# Altium schematic sheet Sheet1.SchDoc(Sheet1)
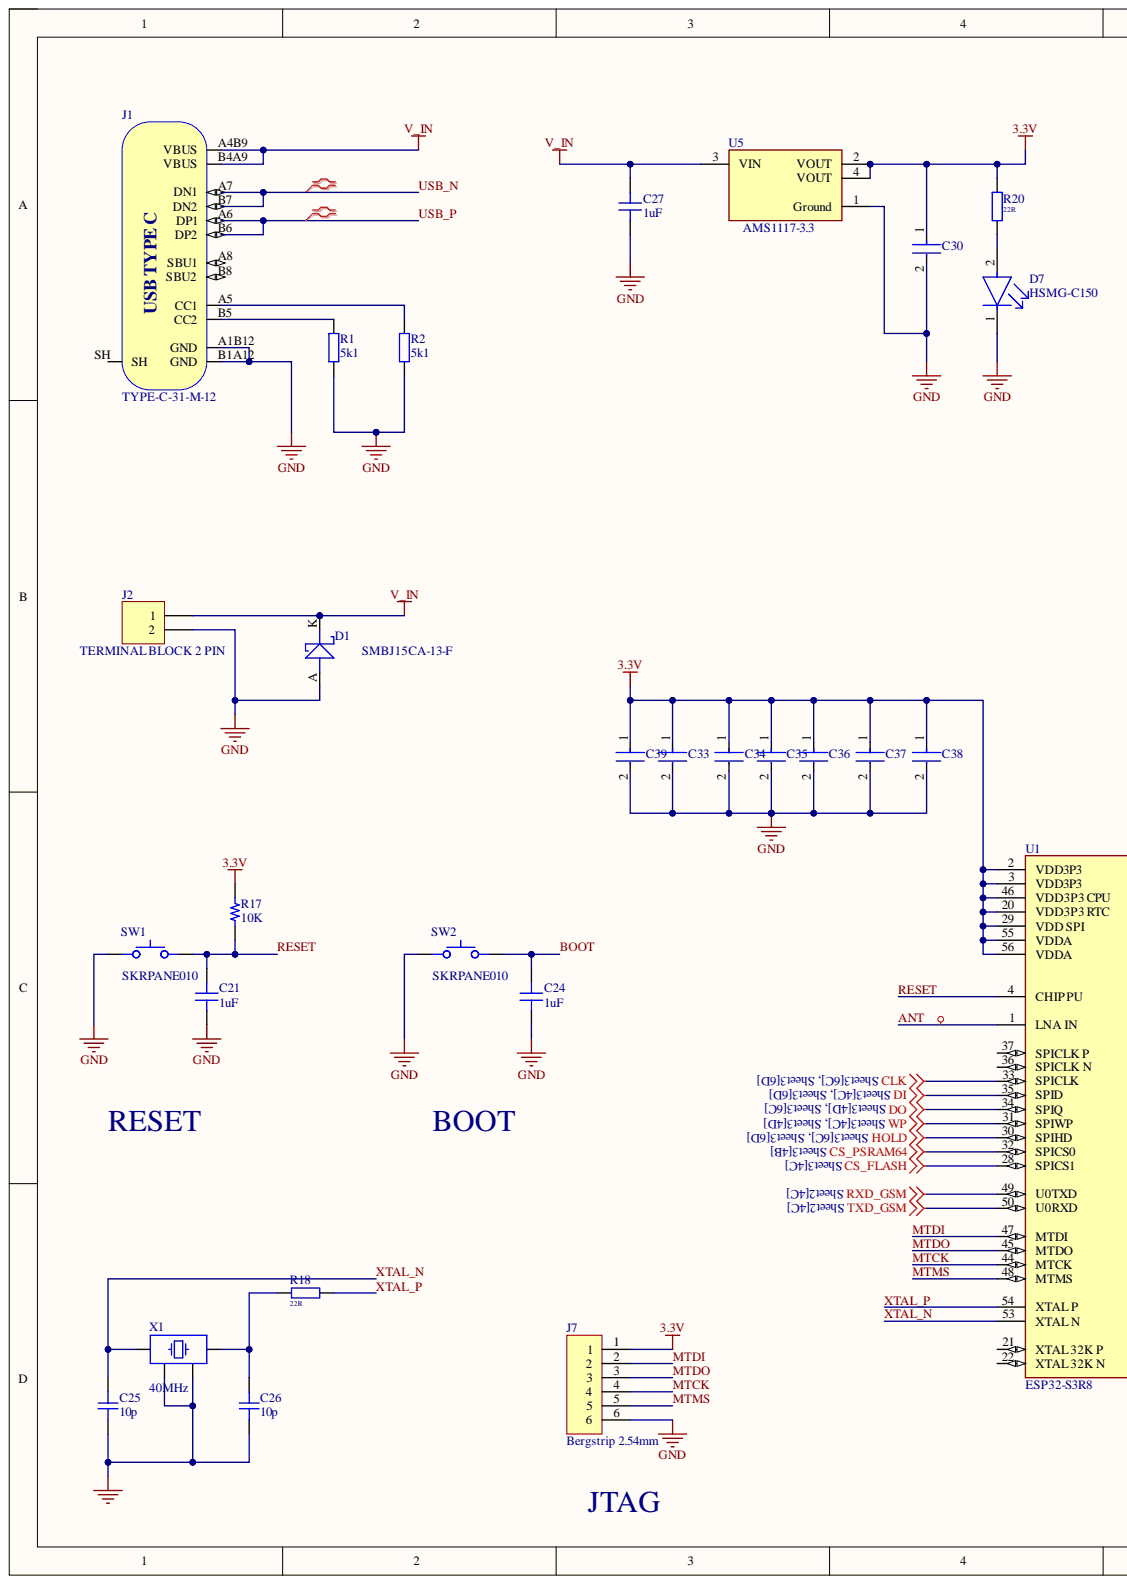
[diagram p0: Sheet1.SchDoc, left]
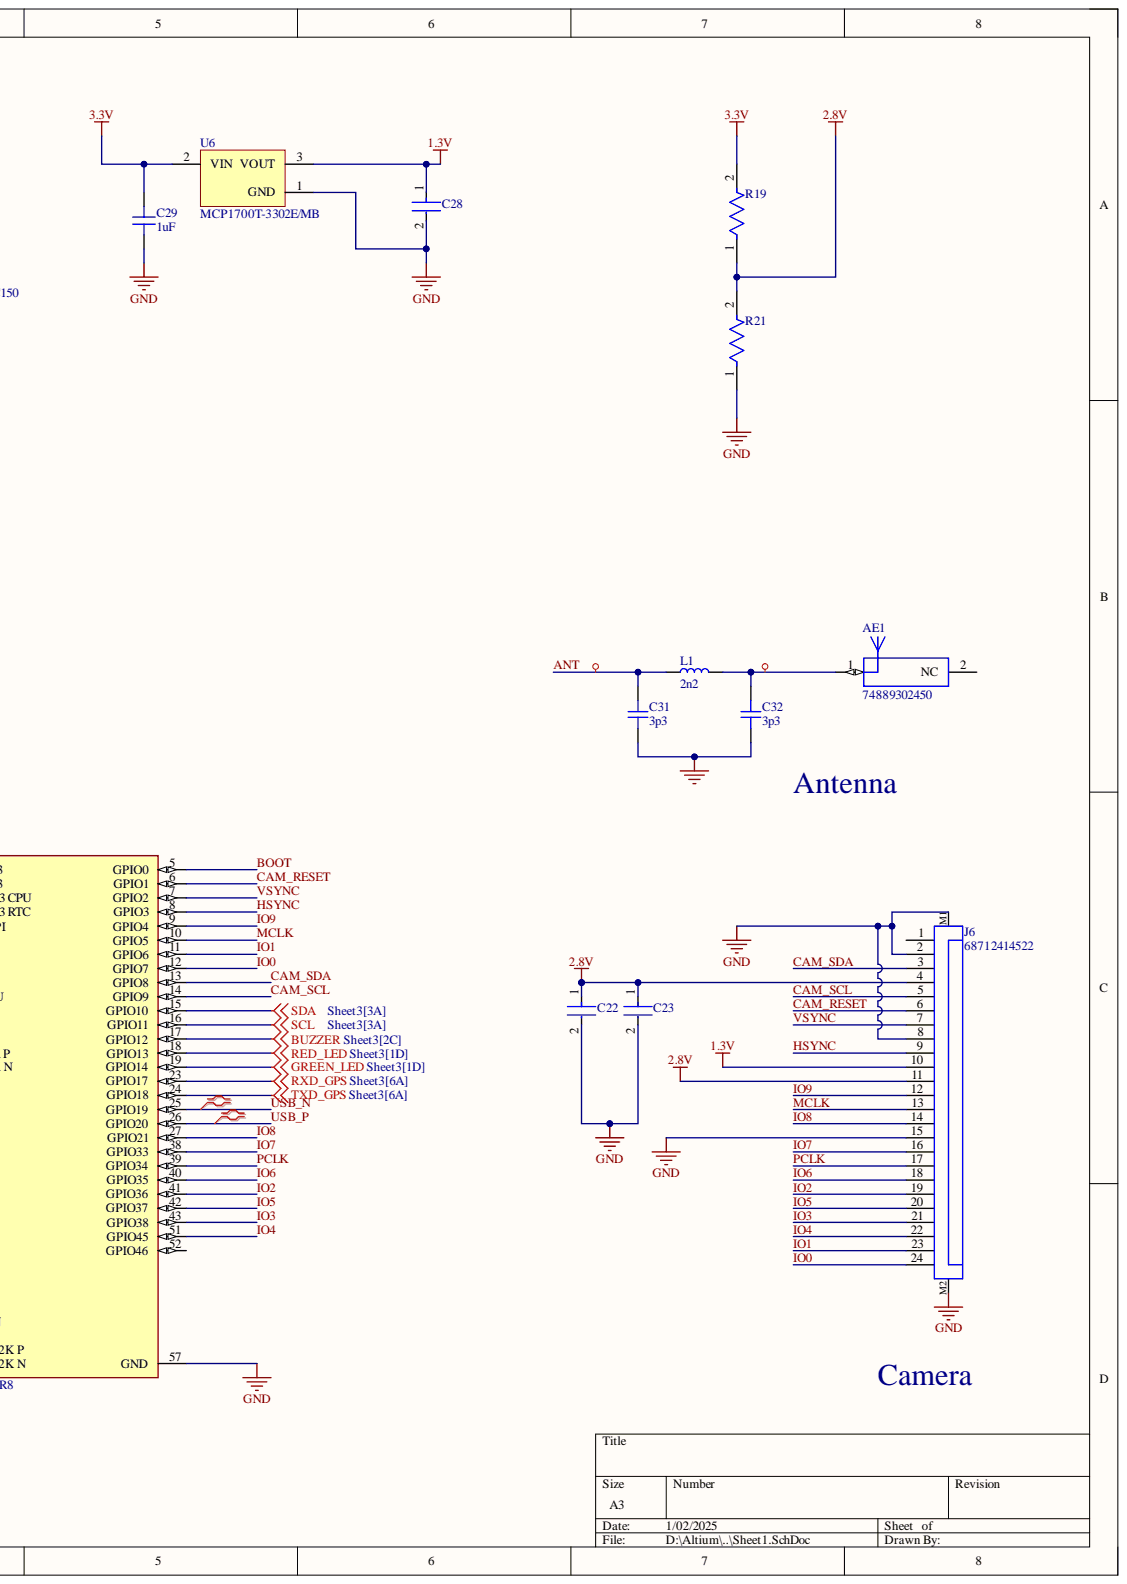
[diagram p1: Sheet1.SchDoc, right]

## Parts
AE1  74889302450  [74889302450]
C21  1uF  [CAP 0402_1005]
C22  C0402C104K4RACTU  [FP-C0402-BB-MFG]  (value not drawn)
C23  C0402C104K4RACTU  [FP-C0402-BB-MFG]  (value not drawn)
C24  1uF  [CAP 0402_1005]
C25  10p  [CAP_0402]
C26  10p  [CAP_0402]
C27  1uF  [CAP 0402_1005]
C28  C0402C104K4RACTU  [FP-C0402-BB-MFG]  (value not drawn)
C29  1uF  [CAP 0402_1005]
C30  C0402C104K4RACTU  [FP-C0402-BB-MFG]  (value not drawn)
C31  3p3  [CAP_0402]
C32  3p3  [CAP_0402]
C33  C0402C104K4RACTU  [FP-C0402-BB-MFG]  (value not drawn)
C34  C0402C104K4RACTU  [FP-C0402-BB-MFG]  (value not drawn)
C35  C0402C104K4RACTU  [FP-C0402-BB-MFG]  (value not drawn)
C36  C0402C104K4RACTU  [FP-C0402-BB-MFG]  (value not drawn)
C37  C0402C104K4RACTU  [FP-C0402-BB-MFG]  (value not drawn)
C38  C0402C104K4RACTU  [FP-C0402-BB-MFG]  (value not drawn)
C39  C0402C104K4RACTU  [FP-C0402-BB-MFG]  (value not drawn)
D1  SMBJ15CA-13-F  [DIO_SMB_DO-214AA]
D7  HSMG-C150  [FP-HSMG-C150-MFG]
J1  TYPE-C-31-M-12  [TYPE-C-31-M-12]
J2  TERMINAL BLOCK 2 PIN  [CON2_P196_1729128_TH_ST]
J6  68712414522  [68712414522]
J7  Bergstrip 2.54mm  [CON6_100_59D78_TH_ST]
L1  2n2  [IND_0402]
R1  5k1  [RES_0402]
R2  5k1  [RES_0402]
R17  10K  [RES 0402_1005]
R18  22R  [RES_0402]
R19  CRCW06031K00FKEA  [FP-CRCW0603-e3-MFG]  (value not drawn)
R20  22R  [RES_0402]
R21  CRCW06035K60FKEA  [FP-CRCW0603-e3-MFG]  (value not drawn)
SW1  SKRPANE010  [SKRPANE010]
SW2  SKRPANE010  [SKRPANE010]
U1  ESP32-S3R8  [FP-ESP32-S3R8-IPC_A]
U5  AMS1117-3.3  [FP-AMS1117-IPC_C]
U6  MCP1700T-3302E/MB  [SOT-89-MB3_M]
X1  40MHz  [XTAL_2520]

## Nets
1.3V: C28.1 J6.10 U6.3
2.8V: C22.1 C23.1 J6.4 J6.11 R19.1 R21.2
3.3V: C29.2 C30.1 C33.1 C34.1 C35.1 C36.1 C37.1 C38.1 C39.1 J7.1 R17.2 R19.2 R20.1 U1.2(VDD3P3) U1.3(VDD3P3) U1.20(VDD3P3_RTC) U1.29(VDD_SPI) U1.46(VDD3P3_CPU) U1.55(VDDA) U1.56(VDDA) U5.2(VOUT) U5.4(VOUT) U6.2
BUZZER: U1.17(GPIO12)
CLK: U1.33(SPICLK)
CS_FLASH: U1.28(SPICS1)
CS_PSRAM64: U1.32(SPICS0)
DI: U1.35(SPID)
DO: U1.34(SPIQ)
GND: C21.1 C22.2 C23.2 C24.1 C25.1 C26.1 C27.1 C28.2 C29.1 C30.2 C31.1 C32.1 C33.2 C34.2 C35.2 C36.2 C37.2 C38.2 C39.2 D1.A D7.1 J1.A1B12(GND) J1.B1A12(GND) J2.2 J6.2 J6.8 J6.15 J6.M1 J6.M2 J7.6 R1.1 R2.1 R21.1 SW1.12 SW2.12 U1.57(GND) U5.1(Ground) U6.1 X1.2 X1.4
GREEN_LED: U1.19(GPIO14)
HOLD: U1.30(SPIHD)
RED_LED: U1.18(GPIO13)
RXD_GPS: U1.23(GPIO17)
RXD_GSM: U1.49(U0TXD)
SCL: U1.16(GPIO11)
SDA: U1.15(GPIO10)
TXD_GPS: U1.24(GPIO18)
TXD_GSM: U1.50(U0RXD)
V_IN: C27.2 D1.K J1.A4B9(VBUS) J1.B4A9(VBUS) J2.1 U5.3(VIN)
WP: U1.31(SPIWP)
ANT: C31.2 L1.2 U1.1(LNA_IN)
BOOT: C24.2 SW2.34 U1.5(GPIO0)
CAM_RESET: J6.6 U1.6(GPIO1)
CAM_SCL: J6.5 U1.14(GPIO9)
CAM_SDA: J6.3 U1.13(GPIO8)
HSYNC: J6.9 U1.8(GPIO3)
IO0: J6.24 U1.12(GPIO7)
IO1: J6.23 U1.11(GPIO6)
IO2: J6.19 U1.41(GPIO36)
IO3: J6.21 U1.43(GPIO38)
IO4: J6.22 U1.51(GPIO45)
IO5: J6.20 U1.42(GPIO37)
IO6: J6.18 U1.40(GPIO35)
IO7: J6.16 U1.38(GPIO33)
IO8: J6.14 U1.27(GPIO21)
IO9: J6.12 U1.9(GPIO4)
MCLK: J6.13 U1.10(GPIO5)
MTCK: J7.4 U1.44(MTCK)
MTDI: J7.2 U1.47(MTDI)
MTDO: J7.3 U1.45(MTDO)
MTMS: J7.5 U1.48(MTMS)
PCLK: J6.17 U1.39(GPIO34)
RESET: C21.2 R17.1 SW1.34 U1.4(CHIP_PU)
USB_N: J1.A7(DN1) J1.B7(DN2) U1.25(GPIO19)
USB_P: J1.A6(DP1) J1.B6(DP2) U1.26(GPIO20)
VSYNC: J6.7 U1.7(GPIO2)
XTAL_N: C25.2 U1.53(XTAL_N) X1.1
XTAL_P: R18.1 U1.54(XTAL_P)
NetAE1_1: AE1.1 C32.2 L1.1
NetC26_2: C26.2 R18.2 X1.3
NetD7_2: D7.2 R20.2
NetJ1_A5: J1.A5(CC1) R2.2
NetJ1_B5: J1.B5(CC2) R1.2

Not connected: AE1 2; J1 A8(SBU1) B8(SBU2) SH; J6 1; U1 21(XTAL_32K_P) 22(XTAL_32K_N) 36(SPICLK_N) 37(SPICLK_P) 52(GPIO46)

## Library data of the parts
AE1 = CMP-03913-126098687-1: 74889302450 Chip Antenna, 2.45Ghz, 3.2Mm X 1.6Mm X 1.2Mm Rohs Compliant: Yes; Current Rating: 2A; Impedance: 50Ω; Component Kind: Standard; Height: 1.2mm; Length: 3.2mm; Library Name: Altium Content Vault; Max Operating Temperature: 85°C; Min Operating Temperature: -40°C; NexarPartId: 126098687; RoHS: Compliant; Width: 1.6mm
C21 C24 C27 C29 = Cap 0402: SMT Capacitor; VALUE: 100nF; Component Kind: Standard; Library Name: Capacitor.IntLib
C22 C23 C28 C30 C33-C39 = CMP-2008-02108-2: CAP CER 0.1UF 16V X7R 0402; Manufacturer: Kemet; Capacitance: 0.10 μF; Material: Ceramic; Temperature Coefficient: 15%; Tolerance: 0.1; Value: 0.10 μF; Automotive: No; Category: Cap; Component Kind: Standard; ComponentLink2Description: Datasheet; ComponentLink2URL: https://content.kemet.com/datasheets/KEM_C1002_X7R_SMD.pdf; Device Class L1: Passive Components; Device Class L2: Capacitors; Device Class L3: Ceramic Capacitors; Digikey Description: CAP CER 0.1UF 16V X7R 0402; Height: 0.55mm; Ipc Land Pattern Name: CAPC10050X50; Lead Free: Yes; Library Name: Altium Content Vault; Manufacturer Part Number: C0402C104K4RACTU; Mouser Description: Cap Ceramic 0.1uF 16V X7R 10% SMD 0402 125?C Paper T/R - Tape and Reel (Alt: C0402C104K4RAC TU); Octopart: https://octopart.com/c0402c104k4ractu-kemet-94158; Package: C0402-BB; RoHS: TRUE; Temperature: -55°C to +125°C; Temperature Characteristic: X7R; Temperature Range High: +125°C; Temperature Range Low: -55°C; Voltage Rating: 16 V
C25 C26 = CAP_0402_50V: Capacitor SMD 0402 50V; Manufacturer: Samsung; Manufacturer Part Number: CL05C100JB5NNNC; Component Kind: Standard; LCSC: C32949; Library Name: Schematic-Lib-PhilsLab.SchLib
C31 C32 = CAP_0402_50V: Capacitor SMD 0402 50V; Manufacturer: FH; Manufacturer Part Number: 0402CG3R3C500NT; Component Kind: Standard; LCSC: C1565; Library Name: Schematic-Lib-PhilsLab.SchLib
D1 = DIO-T_15V-24V-24.6A-DO214AA: 24.4V Clamp 24.6A Ipp Tvs Diode Surface Mount DO-214AA (SMBJ); Alternate Part Number-1: NA; Manufacturer: Nexperia; Case/Package: DO214AA; Component Kind: Standard; Library Name: ESTEEMPCBLIB.IntLib; Manufacturer Part Number: SMBJ15CA-13-F; Supplier Part Number-1: SMBJ15CA-13-F; Supplier-1: SIRICOM
D7 = CMP-2000-05061-2: LED GREEN DIFFUSED 1206 SMD; Manufacturer: Broadcom; Forward Current: 20mA; Forward Voltage: 2.2V; Led Orientation: Top View; Luminous Intensity: 15mcd; Max Junction Temp: +95°C; Peak Emmision Wavelength: 570nm; Power Dissipation: 65mW; Reverse Voltage: 5V; Viewing Angle: 170°; Wavelength: 572nm; Automotive: No; Category: Diode; Colour: Green; Component Kind: Standard; ComponentLink2Description: Datasheet; ComponentLink2URL: https://docs.broadcom.com/docs/AV02-0551EN; Device Class L1: Optoelectronics; Device Class L2: LEDs; Device Class L3: unset; Digikey Description: LED GREEN DIFFUSED 1206 SMD; Height: 1.2mm; Ipc Land Pattern Name: DIOCP320160X55; Lead Free: Yes; Library Name: Altium Content Vault; Manufacturer Part Number: HSMG-C150; Mouser Description: LED Uni-Color Green 570nm 2-Pin Chip LED T/R; Octopart: https://octopart.com/hsmg-c150-broadcom-71131304; Package: HSMG-C150; RoHS: TRUE; Temperature: -40°C to +85°C; Temperature Range High: +85°C; Temperature Range Low: -40°C
J1 = TYPE-C-31-M-12: USB Type C Connector Horizontal SMT; Manufacturer: Koren Hroparts; Component Kind: Standard; LCSC: C165948; Manufacturer Part Number: TYPE-C-31-M-12; Library Name: ESP32_USB_Dongle.SCHLIB
J2 = CON-TB_2X1-196-ST-TH: 2 Pins, 1 Row 5mm Pitch, straight Connector, male; Alternate Part Number-1: NA; Manufacturer: Phoenix Contact; Manufacturer Part Number: MKDSN1,5/2; Case/Package: TH-CON; Component Kind: Standard; Library Name: ESTEEMPCBLIB.IntLib; Supplier Part Number-1: MKDSN1,5/2; Supplier-1: SP ROAD
J6 = CMP-1502-01537-2: NexarPartId: 22802324; Actuator Material: Liquid Crystal Polymer, Polymer; Contact Location: Top; Contact Resistance: 20mΩ; Current Rating: 500mA; Gender: Receptacle; Insulation Resistance: 100MΩ; Number of Contacts: 24; Operating Supply Voltage: 50V; Pitch: 500µm; Component Kind: Standard; Contact Material: Bronze, Tin; Contact Plating: Tin; Flammability Rating: UL94 V-0; Housing Material: Liquid Crystal Polymer; Lead Pitch: 500µm; Length: 18.4mm; Library Name: Altium Content Vault; Max Operating Temperature: 85°C; Min Operating Temperature: -25°C; Mount: Surface Mount; Number of Pins: 24; Number of Positions: 24; Number of Rows: 1; Orientation: Horizontal; Packaging: Tape and Reel; REACH SVHC: Unknown; RoHS: Compliant; Termination: SMD/SMT; Voltage Rating: 50V; Width: 2.1mm
J7 = CON-BS-SKT_6X1-100-ST-TH: 6 Pins, 1 Row 2.54mm Pitch, Straight bergstrip, Female; Alternate Part Number-1: NA; Manufacturer: Molex; Case/Package: TH-CON; Component Kind: Standard; Library Name: ESTEEMPCBLIB.IntLib; Manufacturer Part Number: 22-10-2061; Supplier Part Number-1: NA; Supplier-1: SP ROAD
L1 = IND_0402: Inductor SMD 0402; Manufacturer: Murata; Manufacturer Part Number: LQW15AN2N2G80D; Component Kind: Standard; LCSC: C412264; Library Name: Schematic-Lib-PhilsLab.SchLib
R1 R2 = RES_0402: Resistor SMD 0402; Manufacturer: YAGEO; Manufacturer Part Number: RC0402JR-075K1L; Component Kind: Standard; LCSC: C105873; Library Name: ESP32_USB_Dongle.SCHLIB
R17 = Res 0402: SMT Resistor; VALUE: 1K; Component Kind: Standard; Library Name: Resistor.IntLib
R18 R20 = RES_0402: Resistor SMD 0402; Manufacturer: UNI-ROYAL; Manufacturer Part Number: 0402WGF220JTCE; Component Kind: Standard; LCSC: C25092; Library Name: Schematic-Lib-PhilsLab.SchLib
R19 = CMP-1666-00003-5: RES Thick Film, 1kΩ, 1%, 0.1W, 100ppm/°C, 0603; Max Power Dissipation: 100mW; Resistance: 1kR; Voltage Rating: 75V; Case Code (Imperial): 0603; Case Code (Metric): 1608; Case/Package: 0603; Component Kind: Standard; Composition: Thick Film; Contact Plating: Tin; Depth: 0.85mm; Features: Automotive AEC-Q200; Height: 0.45mm; Lead Free: Lead Free; Length: 1.55mm; Library Name: Altium Content Vault; Max Operating Temperature: 155°C; Military Standard: Not; Min Operating Temperature: -55°C; Mount: Surface Mount; Number of Pins: 2; Number of Terminations: 2; Package Quantity: 10; Packaging: Tape and Reel; Power Rating: 100mW; Radiation Hardening: No; Temperature Coefficient: 100ppm/°C; Termination: SMD/SMT; Tolerance: 1%; Voltage Rating (DC): 75V; Weight: 7.1E-05oz; Width: 0.033inch
R21 = CMP-2000-03487-2: RES Thick Film, 5.6kΩ, 1%, 0.1W, 100ppm/°C, 0603; Power Rating: 100mW; Resistance: 5.6kR; Voltage Rating: 75V; Case Code (Imperial): 0603; Case Code (Metric): 1608; Case/Package: 0603; Component Kind: Standard; Composition: Thick Film; Contact Plating: Tin; Depth: 0.85mm; Height: 0.45mm; Lead Free: Lead Free; Length: 1.55mm; Library Name: Altium Content Vault; Max Operating Temperature: 155°C; Military Standard: Not; Min Operating Temperature: -55°C; Mount: Surface Mount; Number of Pins: 2; Number of Terminations: 2; Package Quantity: 5000; Packaging: Tape and Reel; Radiation Hardening: No; Temperature Coefficient: 100ppm/°C; Termination: SMD/SMT; Tolerance: 1%; Voltage Rating (DC): 75V; Weight: 7.1E-05oz; Width: 0.85mm; Working Voltage: 75V
SW1 SW2 = SKRPANE010: Tactile Switch SMD SPST; Manufacturer: Alps Alpine; Component Kind: Standard; LCSC: C470426; Manufacturer Part Number: SKRPANE010; Library Name: ESP32_USB_Dongle.IntLib
U1 = CMP-10700-118292493-1: ESP32-S3R8 RF System on a Chip - SoC SMD IC, Dual-Core MCU, Wi-Fi 2.4G BLE 5.0 Combo; Component Kind: Standard; Library Name: Altium Content Vault; NexarPartId: 118292493
U5 = CMP-209535-000001-1: LDO Voltage Regulators 1A, 3.3V; Manufacturer: Advanced Monolithic Systems; Dropout Voltage: 1.1V; Max Junction Temp: +125°C; Max Power Dissipation: 1.2W; Max Supply Voltage: 15V; Nominal Supply Current: 5mA; Number Of Outputs: 1; Output Type: Fixed; Standoff Height: 0.64mm; Automotive: No; Category: IC; Component Kind: Standard; ComponentLink2Description: Datasheet; ComponentLink2URL: http://www.advanced-monolithic.com/pdf/ds1117.pdf; Device Class L1: Integrated Circuits (ICs); Device Class L2: Power Management ICs; Device Class L3: Voltage Regulators - Linear; Height: 1.8mm; Ipc Land Pattern Name: SOT229P700X180-4; Lead Free: Yes; Library Name: Altium Content Vault; Manufacturer Part Number: AMS1117-3.3; Octopart: https://octopart.com/ams1117-3.3-advanced+monolithic+systems-25899300; Output Current: 1A; Output Voltage: 3.3V; Package: AMS1117; RoHS: TRUE; Temperature: -40°C to +125°C; Temperature Range High: +125°C; Temperature Range Low: -40°C
U6 = CMP-0136-00812-1: Low Quiescent Current LDO, 3-Pin SOT-89, Extended Temperature, Tape and Reel; Code_IPC: SOT89; Component Kind: Standard; DatasheetVersion: revB, Feb-2007; Library Name: Altium Content Vault; PackageDescription: 3-Lead Plastic Small Outline Transistor Header (MB) [SOT-89]; PackageReference: SOT-89-MB3; PackageVersion: revBB, Aug-2009
X1 = XTAL_2520: Crystal SMD 2520; Manufacturer: Seiko Epson; Manufacturer Number: Q24FA20H0020900; Component Kind: Standard; Frequency: 40MHz; LCSC: C255973; Library Name: Schematic-Lib-PhilsLab.SchLib
